# Altium schematic sheet Sheet1.SchDoc
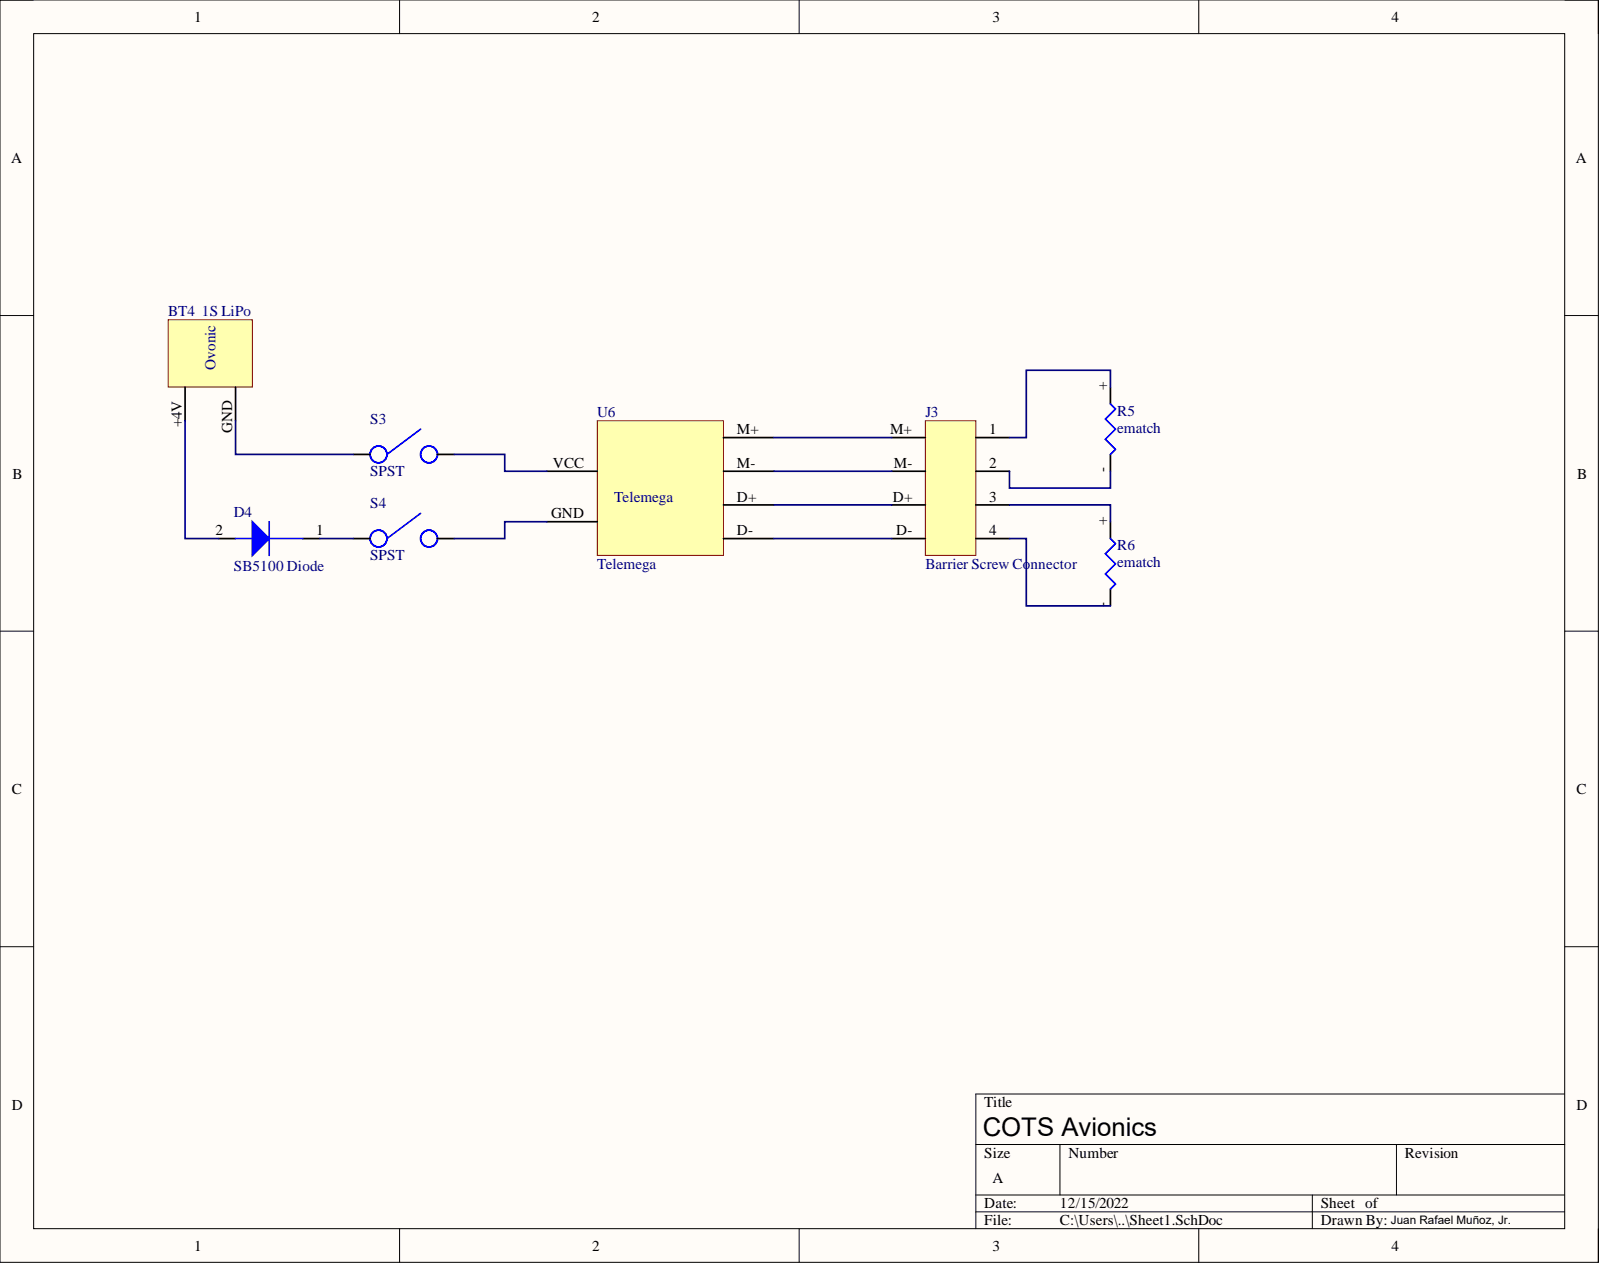
[diagram p0: Sheet1.SchDoc]

## Parts
BT4  1S LiPo  [1S LiPo]
D4  SB5100 Diode  Manufacturer Part Number: SB5100  [Schottky Diode SB5100]
J3  Barrier Screw Connector  Termination: Screw  [Barrier Block Connector]
R5  ematch  [eMatch]
R6  ematch  [eMatch]
S3  SPST  [SPST Switch]
S4  SPST  [SPST Switch]
U6  Telemega

## Nets
NetBT4_+4V: BT4.+4V D4.2
NetBT4_GND: BT4.GND S3.1
NetD4_1: D4.1 S4.1
NetJ3_1: J3.1 R5.+
NetJ3_2: J3.2 R5.-
NetJ3_3: J3.3 R6.+
NetJ3_4: J3.4 R6.-
NetJ3_D+: J3.D+ U6.D+
NetJ3_D-: J3.D- U6.D-
NetJ3_M+: J3.M+ U6.M+
NetJ3_M-: J3.M- U6.M-
NetS3_2: S3.2 U6.VCC
NetS4_2: S4.2 U6.GND

## Library data of the parts
BT4 = 1S LiPo: 1S 3.7-4.2V Lithium Polymer battery from Ovonic with 750mAh and 30C; Component Kind: Standard; Library Name: Schlib1.SchLib
D4 = Schottky Diode SB5100: DIODE SCHOTTKY 100V 5A DO201AD; Manufacturer: ON Semiconductor / Fairchild; Element Configuration: Single; Forward Voltage: 850mV; Max Forward Surge Current (Ifsm): 100A; Max Reverse Current: 500uA; Max Surge Current: 150A; Polarity: Standard; Average Rectified Current: 5A; Case/Package: DO-201AD; Component Kind: Standard; ComponentLink1Description: https://datasheet.ciiva.com/911/sb5100-911093.pdf?src-supplier=Avnet; ComponentLink1URL: https://datasheet.ciiva.com/911/sb5100-911093.pdf?src-supplier=Avnet; ComponentLink2Description: https://datasheet.ciiva.com/4714/sb5100-191746-4714390.pdf?src-supplier=Mouser; ComponentLink2URL: https://datasheet.ciiva.com/4714/sb5100-191746-4714390.pdf?src-supplier=Mouser; ComponentLink3Description: https://datasheet.ciiva.com/7965/78621-7965207.pdf?src-supplier=Element14; ComponentLink3URL: https://datasheet.ciiva.com/7965/78621-7965207.pdf?src-supplier=Element14; ComponentLink4Description: https://datasheet.ciiva.com/911/sb5100-911279.pdf?src-supplier=Digi-Key; ComponentLink4URL: https://datasheet.ciiva.com/911/sb5100-911279.pdf?src-supplier=Digi-Key; ComponentLink5Description: https://datasheet.ciiva.com/911/sb5100-911278.pdf?src-supplier=Digi-Key; ComponentLink5URL: https://datasheet.ciiva.com/911/sb5100-911278.pdf?src-supplier=Digi-Key; Current Rating: 5A; Diameter: 5.6mm; Forward Current: 5A; Height: 9.5mm; Lead Free: Lead Free; Length: 0.25inch; Library Name: Schlib1.SchLib; Max Operating Temperature: 150°C; Max Repetitive Reverse Voltage (Vrrm): 100V; Max Reverse Leakage Current: 500uA; Max Reverse Voltage (DC): 100V; Min Operating Temperature: -50°C; Mount: Through Hole; Number of Pins: 2; Output Current: 5A; Package Quantity: 1; Packaging: Tape and Reel; Peak Non-Repetitive Surge Current: 150A; Pricing 1: 1=1.21000 (USD); Radiation Hardening: No; Reach SVHC Compliant: Yes; RoHS: Yes; RoHS Compliant: Yes; Series: -; Stock 1: 1; Supplier 1: Digi-Key; Supplier Part Number 1: SB5100FSCT-ND; Voltage Rating (DC): 100V; Weight: 0.36g; Width: 0.25inch
J3 = Barrier Block Connector: 4 Circuit 0.437" (11.10mm) Barrier Block Connector Screws 25A 300V 14-22 AWG; Manufacturer: TE Connectivity; Manufacturer Part Number: 1546670-4; Approvals: UL; Mounting Ears: Yes (With); Mounting Insert: No (Without); Number of Circuits: 4; Number of Contacts: 8; Number of Levels: 1; Pitch: 11.1mm; Thread Size: #6-32; Wire Gauge (Max): 22AWG; Category: Terminal Blocks; Color: Black; Component Kind: Standard; ComponentLink1Description: https://datasheet.ciiva.com/30492/0900766b8122207d-30492418.pdf?src-supplier=RSComponents; ComponentLink1URL: https://datasheet.ciiva.com/30492/0900766b8122207d-30492418.pdf?src-supplier=RSComponents; ComponentLink2Description: https://datasheet.ciiva.com/776/eng-cd-1546670-k18-776752.pdf?src-supplier=Digi-Key; ComponentLink2URL: https://datasheet.ciiva.com/776/eng-cd-1546670-k18-776752.pdf?src-supplier=Digi-Key; ComponentLink3Description: https://datasheet.ciiva.com/3141/eng-cs-1308389-barrier-strips-0607-3141462.pdf?src-supplier=Avnet; ComponentLink3URL: https://datasheet.ciiva.com/3141/eng-cs-1308389-barrier-strips-0607-3141462.pdf?src-supplier=Avnet; ComponentLink4Description: https://datasheet.ciiva.com/30215/eng-cs-1308389-barrier-strips-0607-30215653.pdf?src-supplier=Onlinecomponents.com; ComponentLink4URL: https://datasheet.ciiva.com/30215/eng-cs-1308389-barrier-strips-0607-30215653.pdf?src-supplier=Onlinecomponents.com; ComponentLink5Description: https://datasheet.ciiva.com/15169/getdatasheetpartid-154556-15169541.pdf?src-supplier=Verical; ComponentLink5URL: https://datasheet.ciiva.com/15169/getdatasheetpartid-154556-15169541.pdf?src-supplier=Verical; ComponentLink6Description: https://datasheet.ciiva.com/16794/70088626-16794325.pdf?src-supplier=Allied+Electronics; ComponentLink6URL: https://datasheet.ciiva.com/16794/70088626-16794325.pdf?src-supplier=Allied+Electronics; Contact Material: Brass; Contact Plating: Tin; Current Rating: 25A; Depth: 27.94mm; ELV: Compliant; Flammability Rating: UL94 V-0; Housing Color: Black; Housing Material: Thermoplastic; Insulation Material: Thermoplastic; Lead Free: Lead Free; Length: 2.496inch; Library Name: Schlib1.SchLib; Material: Thermoplastic; Max Current Rating: 25A; Max Operating Temperature: 248°C; Max Supply Voltage (AC): 300V; Max Voltage Rating (AC): 300V; Min Operating Temperature: -40°C; Mount: Panel,Chassis; Number of Poles: 4; Number of Positions: 4; Number of Rows: 2; Number of Wire Entries: 8; Orientation: Straight; Package Quantity: 100; Packaging: Carton; Pricing 1: 1=2.65000, 10=2.00300, 25=1.85680, 50=1.66940, 100=1.62860, 250=1.42508, 500=1.30292, 1000=1.18077, 5000=1.03826 (USD); Radiation Hardening: No; RoHS: Yes; RoHS Compliant: Yes; Series: Buchanan; Stock 1: 760; Supplier 1: Digi-Key; Supplier Part Number 1: A98516-ND; Terminal Finish: Nickel; Terminal Material: Brass; Voltage: 300V; Voltage Rating: 300V; Wire Gauge (Min): 22AWG; Wire/Cable Gauge: 22AWG
R5 R6 = eMatch: An eMatch used for ejection charges. 0.1R 0.5-1.0A; Component Kind: Standard; Library Name: Schlib1.SchLib
S3 S4 = SPST Switch: Product Name : Push Button Switch;Model : DS228;Action Type : Latching  Contact configuration : SPST;Rating Voltage : AC 120V 3AAC 250V 1.5A;Terminals Quantity : 2  Mounting Hole Diameter : 12mm / 0.47";Button Diameter : 9mm/ 0.35";Overall Size : 16 x 22mm/ 0.63" x 0.87"(D*H)  Material : Plastic & Metal;Color : Red, Black  Net Weight : 31g;Package Content : 10 x Push Button Switch; Component Kind: Standard; Library Name: Schlib1.SchLib
U6 = Telemega: The Telemega is a COTS avionics system used for single or dual parachute deployment recovery systems in high power rocketry.; Component Kind: Standard; Library Name: Schlib1.SchLib
